# Altium schematic sheet Timer.SchDoc
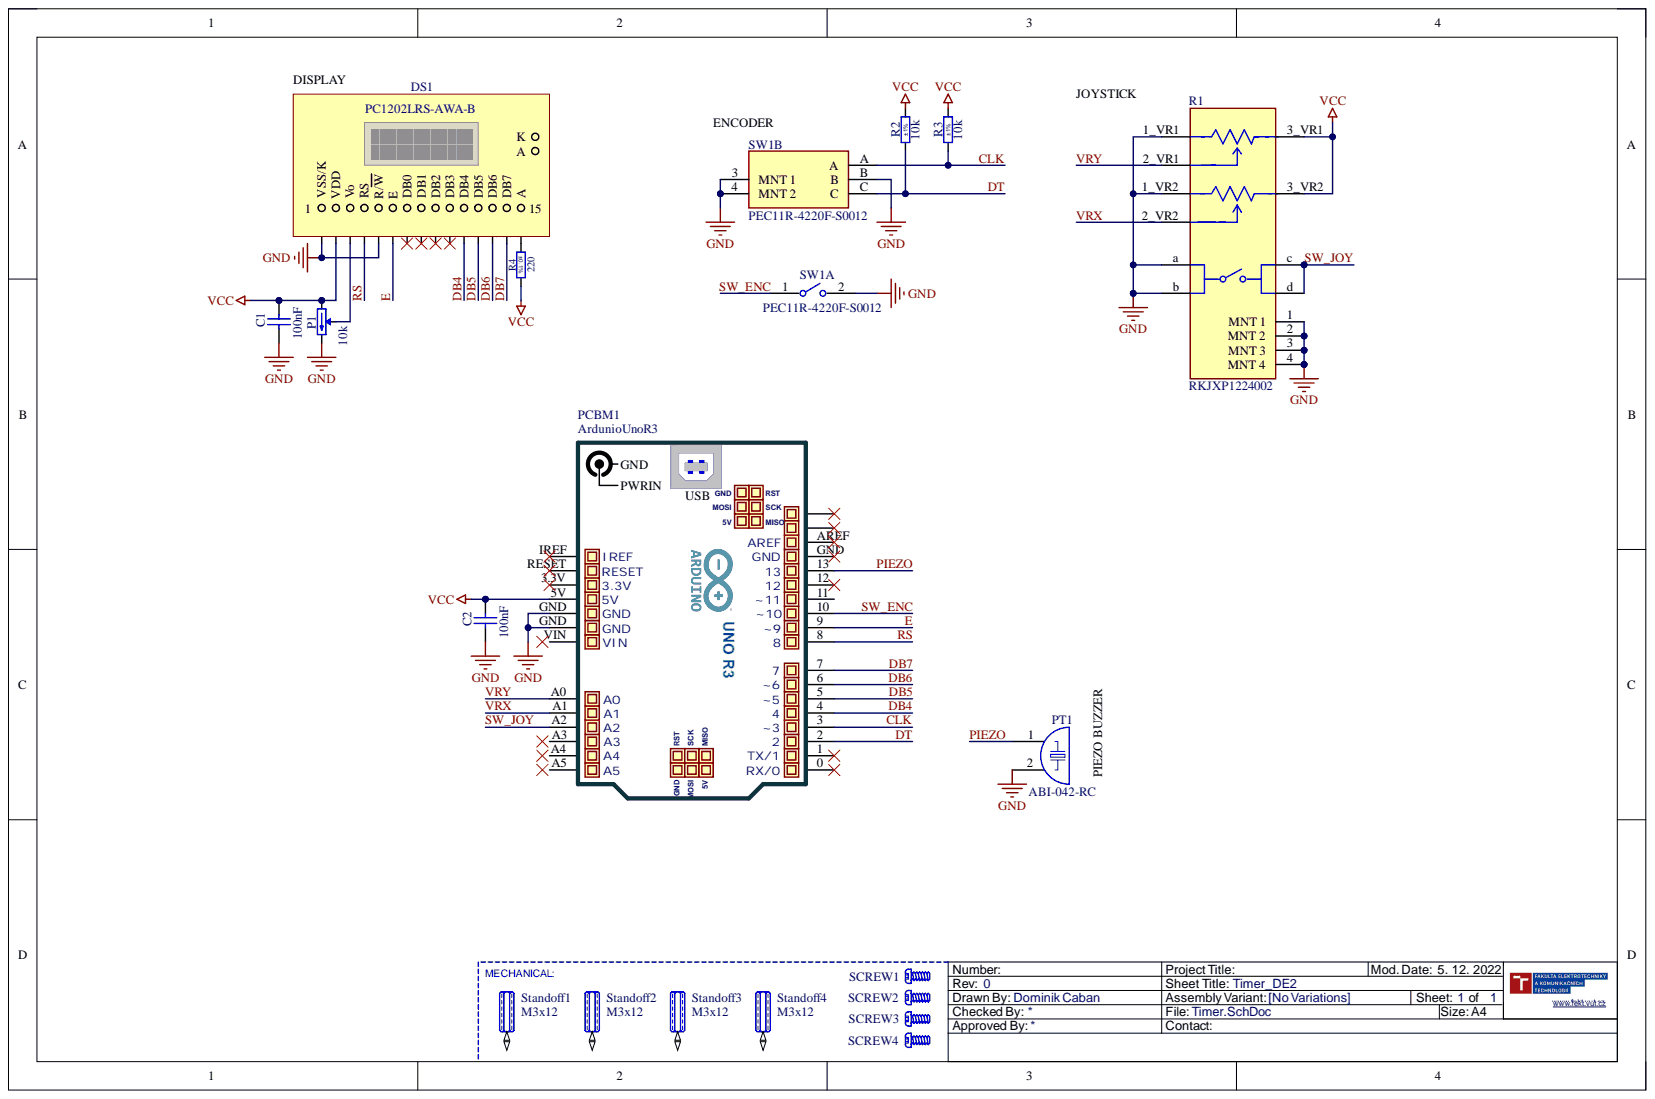
[diagram p0: Timer.SchDoc]

## Parts
C1  100nF  [CAPC2013X145N]
C2  100nF  [CAPC2013X145N]
DS1  PC1202LRS-AWA-B  [DS_POWERTIP_PC1202LRS-AWA-B]
J1  Header 15  [SAMTEC_TMS-115-01-L-S]  (value not drawn)
P1  10k  [TRIM_BOURNS_3314G-1]
PCBM1  ArdunioUnoR3
PT1  ABI-042-RC  [ABI-042-RC]
R1  RKJXP1224002  [FP-RKJXP1224002-MFG]
R2  10k  Tolerance: ±1%  [RESC1608X55N]
R3  10k  Tolerance: ±1%  [RESC1608X55N]
R4  220  Tolerance: ±0.1%  [RESC1608X64N]
SCREW1  #4-24 x 5/16''  [3M_3341-5_v]  (value not drawn)
SCREW2  #4-24 x 5/16''  [3M_3341-5_v]  (value not drawn)
SCREW3  #4-24 x 5/16''  [3M_3341-5_v]  (value not drawn)
SCREW4  #4-24 x 5/16''  [3M_3341-5_v]  (value not drawn)
Standoff1  M3x12  [BOSSARD_BN3320-1382721]
Standoff2  M3x12  [BOSSARD_BN3320-1382721]
Standoff3  M3x12  [BOSSARD_BN3320-1382721]
Standoff4  M3x12  [BOSSARD_BN3320-1382721]
SW1  PEC11R-4220F-S0012  [FP-PEC11R-4220F-S0012-MFG]  (parts A,B)

## Nets
GND: C1.2 C2.2 J1.1 J1.5(R/W) P1.3 PCBM1.GND PT1.2 R1.1(MNT_1) R1.1_VR1 R1.1_VR2 R1.2(MNT_2) R1.3(MNT_3) R1.4(MNT_4) R1.a R1.b SW1.2 SW1.3(MNT_1) SW1.4(MNT_2) SW1.B
VCC: C1.1 C2.1 J1.2(VDD) P1.1 PCBM1.5V R1.3_VR1 R1.3_VR2 R2.2 R3.2 R4.2
CLK: PCBM1.3 R3.1 SW1.A
DB4: J1.11(DB4) PCBM1.4
DB5: J1.12(DB5) PCBM1.5
DB6: J1.13(DB6) PCBM1.6
DB7: J1.14(DB7) PCBM1.7
DT: PCBM1.2 R2.1 SW1.C
E: J1.6(E) PCBM1.9(~9)
PIEZO: PCBM1.13 PT1.1
RS: J1.4(RS) PCBM1.8
SW_ENC: PCBM1.10(~10) SW1.1
SW_JOY: PCBM1.A2 R1.c R1.d
VRX: PCBM1.A1 R1.2_VR2
VRY: PCBM1.A0 R1.2_VR1
NetJ1_3: J1.3(Vo) P1.2
NetJ1_15: J1.15(A) R4.1

Not connected: J1 7(DB0) 8(DB1) 9(DB2) 10(DB3); PCBM1 0 1 3.3V 11(~11) 12 A3 A4 A5 AREF IREF RESET VIN; Standoff1 1; Standoff2 1; Standoff3 1; Standoff4 1

## Library data of the parts
C1 C2 = CC0805_100NF_50V_10%_X7R_VISHAY_VJ_NON-MAGNETIC: Non-polarised capacitor; Manufacturer: VISHAY VITRAMON; Manufacturer Part Number: VJ0805Y104KCAA; Part Description: Surface Mount Multilayer Ceramic Chip Capacitors for Non-Magnetic Applications (IR Reflow Soldering); Database Table Name: Capacitors SMD; TC: X7R; Tolerance: ±10%; Voltage: 50V; Case: 0805; Component Kind: Standard; Component Type: Standard; ComponentHeight: 1.45mm; CreateDate: 22. 6. 2016; HelpURL: \\cern.ch\dfs\Applications\Altium\Datasheets\CC0805_VISHAY_VJ_NON-MAGNETIC.pdf; LatestRevisionDate: 22. 6. 2016; Library Name: Capacitors.DbLib; Mounted: Yes; Part Number: CC0805_100NF_50V_10%_X7R_VISHAY_VJ_NON-MAGNETIC; Pin Count: 2; Sense: No; SMD: Yes; Socket: No; Status: None; Value: 100nF; Author: CERN DEM MR
DS1 = DS_POWERTIP_PC1202LRS-AWA-B: Alphanumeric LCD Display Module, 2 x 12 characters, Dot Size:0.45 x 0.60, Dot Pitch 0.55 x 0.70; Part Description: STN positive gray, Alphanumeric LCD Display Module, 2 x 12 characters With LED Backlight Yellow-Green; Bonding: No; Database Table Name: LEDs & Displays; PressFit: No; Component Kind: Standard; Component Type: Standard; ComponentHeight: 12.8mm; CreateDate: 30. 5. 2011; Device: PC1202LRS-AWA-B; HelpURL: \\cern.ch\dfs\Applications\Altium\Datasheets\DS_POWERTIP_PC1202LRS-AWA-B.pdf; LatestRevisionDate: 30. 5. 2011; Library Name: ICs And Semiconductors.DbLib; Manufacturer: POWERTIP; Manufacturer Part Number: PC1202LRS-AWA-B; Mounted: Yes; PackageDescription: Alphanumeric LCD Display Module, 2 x 12 characters, Dot Size:0.45 x 0.60, Dot Pitch 0.55 x 0.70, With LED Backlight Yellow-Green; Part Number: DS_POWERTIP_PC1202LRS-AWA-B; Pin Count: 0; Sense: No; SMD: No; Socket: No; Author: CERN DEM JLC
J1 = SAMTEC_TMS-115-01-L-S: Connector 15 Male; Part Description: 15 Contacts, Pitch 1.27mm, Single Row (SIL) Through Mount Header; Database Table Name: SAMTEC; PressFit: No; Component Kind: Standard; Component Type: Standard; ComponentHeight: 8.13mm; CreateDate: 27. 3. 2013; Family: Header 15; HelpURL: \\cern.ch\dfs\Applications\Altium\Datasheets\SAMTEC_TMS-115-01-L-S.pdf; LatestRevisionDate: 27. 3. 2013; Library Name: Connectors.DbLib; Manufacturer: SAMTEC; Manufacturer Part Number: TMS-115-01-L-S; Mounted: Yes; Part Number: SAMTEC_TMS-115-01-L-S; Pin Count: 15; Sense: No; SMD: No; Socket: No; Status: None; Author: CERN DEM JLC
P1 = TRIM_1T_10K_BOURNS_3314G-1-103E: Trimming Potentiometer; Manufacturer: BOURNS; Part Description: Single Turn 4mm Square Trimpot® Trimming Potentiometer (Top Adjust); Database Table Name: Potentiometers SMD; Power: 0.25W; PressFit: No; TC: ±100ppm/°C; Tolerance: ±20%; Case: 3314G-1; Component Kind: Standard; Component Type: Standard; ComponentHeight: 2.55mm; CreateDate: 4. 3. 2014; HelpURL: \\cern.ch\dfs\Applications\Altium\Datasheets\TRIM_BOURNS_3314G-1.pdf; LatestRevisionDate: 4. 3. 2014; Library Name: Resistors.DbLib; Manufacturer Part Number: 3314G-1-103E; Mounted: Yes; Part Number: TRIM_1T_10K_BOURNS_3314G-1-103E; Pin Count: 3; Sense: No; SMD: Yes; Socket: No; Value: 10k; Author: CERN DEM JLC
PCBM1 = ArdunioUnoR3: ArdunioUnoR3 Universal SCH Symbol   (C) 230232; Component Kind: Standard; Library Name: ArduinoUnoR3V2.SchLib
PT1 = ABI-042-RC: Piezo Transducer; Manufacturer: ABCOMPONENTS; Part Description: Piezo Transducer, Audio Indicator, Buzzer; Bonding: No; Database Table Name: Sensors; PressFit: No; Component Kind: Standard; Component Type: Standard; ComponentHeight: 9.7mm; CreateDate: 2. 5. 2019; Device: ABI-042-RC; HelpURL: \\cern.ch\dfs\Applications\Altium\Datasheets\ABI-042-RC.pdf; LatestRevisionDate: 2. 5. 2019; Library Name: ICs And Semiconductors.DbLib; Manufacturer Part Number: ABI-042-RC; Mounted: Yes; PackageDescription: ABComponent Piezo Transducer Buzzer, Body Dia. 12mm, Pitch 7.6mm; Part Number: ABI-042-RC; Pin Count: 2; Sense: No; SMD: No; Socket: No; Author: CERN DEM BR
R1 = CMP-24118-000004-1: Multi-Directional Switches LEVER RTRN CNTR PUSH; Current Rating: 50mA; Life (Hours): 2000Hour; Power Rating: 12.5mW; Resistance: 10kR; Component Kind: Standard; Depth: 21.5mm; Height: 12.2mm; Length: 16mm; Library Name: Altium Content Vault; Max Operating Temperature: 70°C; Military Standard: Not; Min Operating Temperature: -10°C; Mount: Through Hole; Package Quantity: 700; Packaging: Tray; Radiation Hardening: No; Resistor Type: Potentiometer; Series: RKJXP; Termination: Pin; Type: Potentiometer; Width: 16mm
R2 R3 = R0603_10K_1%_0.1W_100PPM: Resistor - 1%; Manufacturer: GENERIC; Manufacturer1 Part Number: NRC06F1002TRF; Part Description: General Purpose Thick Film Chip Resistor; Database Table Name: Resistors SMD; Power: 0.1W; PressFit: No; TC: ±100ppm/°C; Case: 0603; Component Kind: Standard; Component Type: Standard; CreateDate: 3. 12. 2007; HelpURL: \\cern.ch\dfs\Applications\Altium\Datasheets\R0603_NIC_NRC.pdf; LatestRevisionDate: 13. 3. 2008; Library Name: Resistors.DbLib; Manufacturer1 ComponentHeight: 0.55mm; Manufacturer1 Example: NIC COMPONENT; Manufacturer Part Number: R0603_10K_1%_0.1W_100PPM; Mounted: Yes; Part Number: R0603_10K_1%_0.1W_100PPM; Pin Count: 2; Sense: No; SMD: Yes; Socket: No; Status: Preferred; Value: 10k; Author: CERN DEM JLC
R4 = R0603_220R_0.1%_0.1W_25PPM: Resistor - 0.1%; Manufacturer: GENERIC; Manufacturer1 Part Number: ERA3AEB221V; Part Description: Thin Film Chip Resistor; Database Table Name: Resistors SMD; Power: 0.1W; PressFit: No; TC: ±25ppm/°C; Case: 0603; Component Kind: Standard; Component Type: Standard; CreateDate: 12. 1. 2017; HelpURL: \\cern.ch\dfs\Applications\Altium\Datasheets\R0603_PANASONIC_ERA.pdf; LatestRevisionDate: 12. 1. 2017; Library Name: Resistors.DbLib; Manufacturer1 ComponentHeight: 0.55mm; Manufacturer1 Example: PANASONIC; Manufacturer Part Number: R0603_220R_0.1%_0.1W_25PPM; Mounted: Yes; Part Number: R0603_220R_0.1%_0.1W_25PPM; Pin Count: 2; Sense: No; SMD: Yes; Socket: No; Status: Not Recommended; Value: 220; Author: CERN DEM JLC
SCREW1-SCREW4 = 3M_3341-5_v: Screw; Manufacturer: 3M; Manufacturer Part Number: 3341-5; Part Description: #4-24 x 5/16'' (7.94mm) Pan Head Cutting Screw, Fully Threaded, Stainless Steel; Database Table Name: Fasteners & Fixings; PressFit: No; Component Kind: Standard; Component Type: Mechanical; ComponentHeight: 9.94mm; CreateDate: 11. 10. 2019; HelpURL: \\cern.ch\dfs\Applications\Altium\Datasheets\3M_3341-5.pdf; LatestRevisionDate: 11. 10. 2019; Library Name: Eletro-mechanical.DbLib; Mounted: Yes; PackageDescription: #4-24 x 5/16'' (7.94mm) Pan Head Cutting Screw, Fully Threaded, Stainless Steel; Part Number: 3M_3341-5_v; Pin Count: 0; Sense: No; SMD: No; Socket: No; Status: None; Value: #4-24 x 5/16''; Author: CERN DEM BC
Standoff1-Standoff4 = BOSSARD_BN3320-1382721: Standoff Female-Female; Manufacturer Part Number: BN3320 Art.1382721; Part Description: M3 L=12mm, Hex Stand-off With Internal Thread on both sides, Brass, Nickel Plated; Database Table Name: Fasteners & Fixings; PressFit: No; Component Kind: Standard; Component Type: Mechanical; ComponentHeight: 12mm; CreateDate: 25. 9. 2020; HelpURL: \\cern.ch\dfs\Applications\Altium\Datasheets\BOSSARD_BN3320-1382721.pdf; LatestRevisionDate: 25. 9. 2020; Library Name: Eletro-mechanical.DbLib; Manufacturer: BOSSARD; Mounted: Yes; PackageDescription: M3 L=12mm, Hex Stand-off with Internal Thread on both sides, Brass, Nickel Plated; Part Number: BOSSARD_BN3320-1382721; Pin Count: 1; Sense: No; SMD: No; Socket: No; Status: None; Value: M3x12; Author: CERN DEM BC
SW1 = CMP-07421-000036-1: ROTARY ENCODER MECHANICAL 12PPR; Built in Switch: Yes; Hole Diameter: 7mm; Number of Channels: 2; Output Type: Quadrature; Pulses per Revolution: 12; Component Kind: Standard; Detent: Yes; Encoder Type: Mechanical; Height: 13.4mm; Length: 26.5mm; Library Name: Altium Content Vault; Max Operating Temperature: 70°C; Min Operating Temperature: -30°C; Mount: Through Hole; Number of Pins: 5; Orientation: Vertical; Package Quantity: 150; Packaging: Bulk; Radiation Hardening: No; REACH SVHC: No SVHC; RoHS Compliant: Yes; Series: PEC11R; Termination: PC Pin; Type: Mechanical; Width: 12.5mm
